# Altium schematic sheet Microcontroller.SchDoc(Microcontroller)
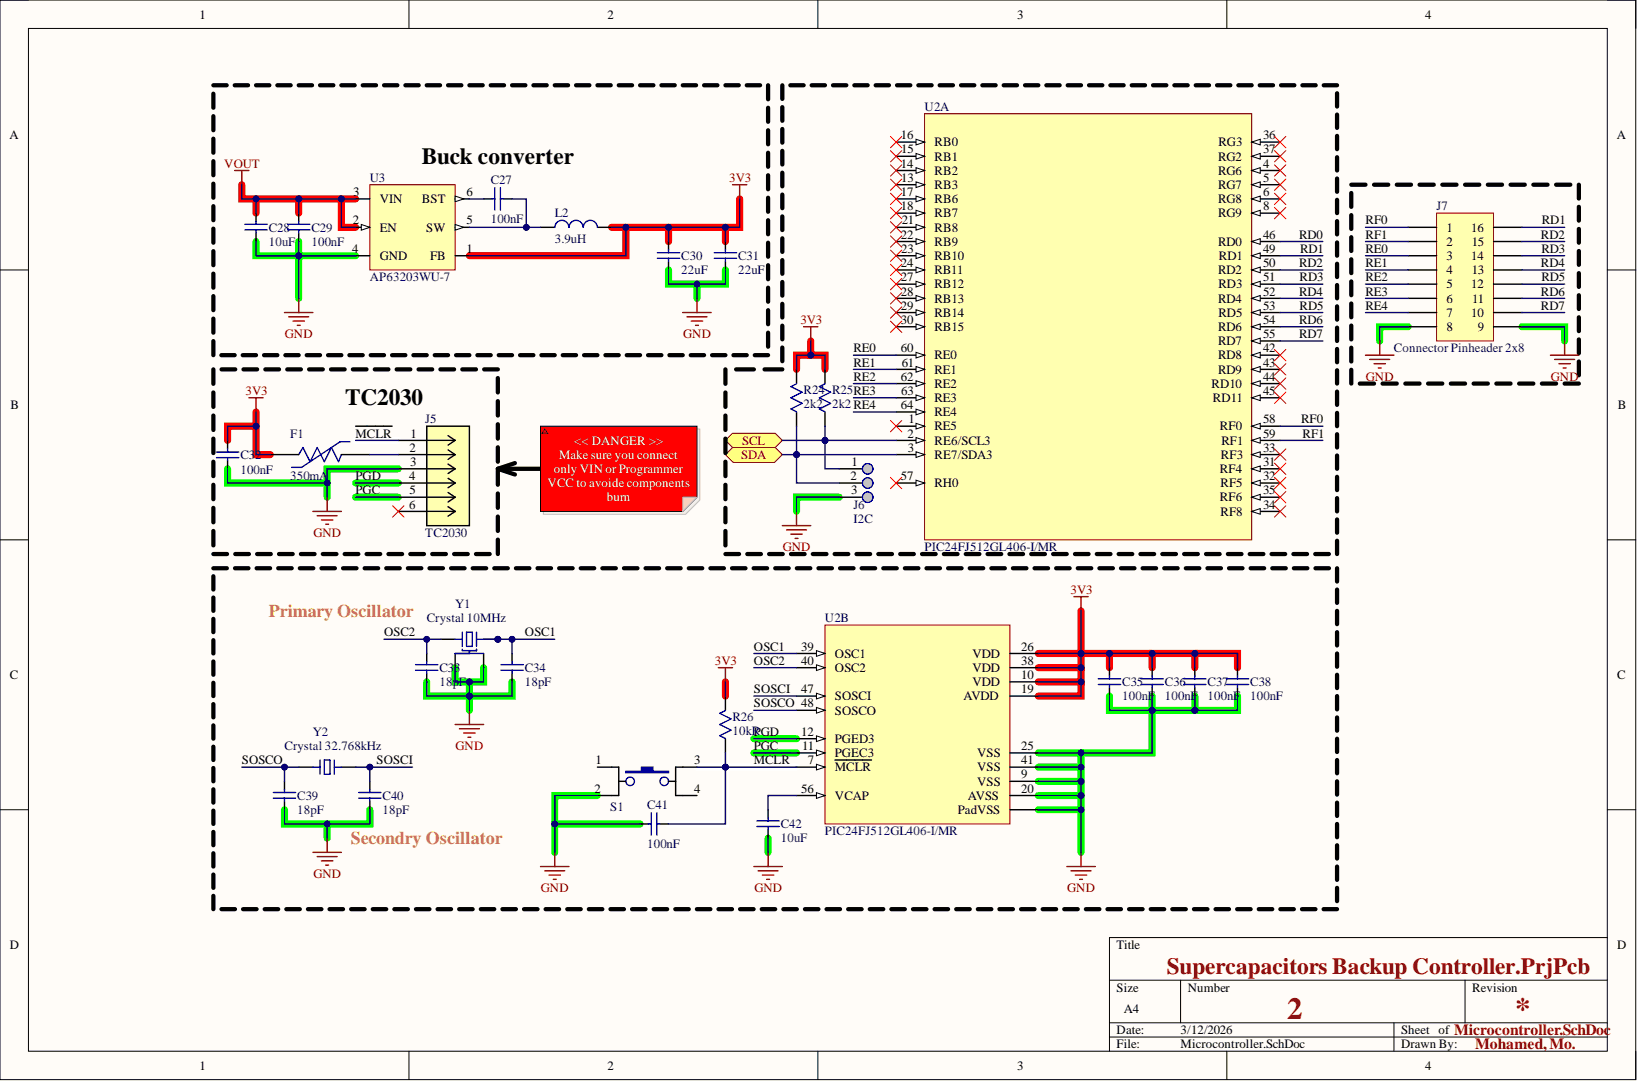
[diagram p0: Microcontroller.SchDoc]

## Parts
C27  100nF
C28  10uF
C29  100nF
C30  22uF
C31  22uF
C32  100nF
C33  18pF
C34  18pF
C35  100nF
C36  100nF
C37  100nF
C38  100nF
C39  18pF
C40  18pF
C41  100nF
C42  10uF
F1  350mA
J5  TC2030  (no BOM)
J6  I2C
J7  Connector Pinheader 2x8
L2  3.9uH
R24  2k2
R25  2k2
R26  10kR
S1  Tactile  (value not drawn)
U2  PIC24FJ512GL406-I/MR  (value not drawn)  (parts A,B)
U3  AP63203WU-7
Y1  Crystal 10MHz
Y2  Crystal 32.768kHz

## Ports
SCL, SDA

## Nets
3V3: C30.1 C31.1 C32.2 C35.1 C36.1 C37.1 C38.1 F1.1 L2.1 R24.2 R25.2 R26.2 U2.10(VDD) U2.19(AVDD) U2.26(VDD) U2.38(VDD) U3.1(FB)
GND: C28.2 C29.2 C30.2 C31.2 C32.1 C33.2 C34.2 C35.2 C36.2 C37.2 C38.2 C39.2 C40.2 C41.1 C42.2 J5.3 J6.3 J7.8 J7.9 S1.2 U2.9(VSS) U2.20(AVSS) U2.25(VSS) U2.41(VSS) U2.65(PadVSS) U3.4(GND) Y1.2 Y1.4
VOUT: C28.1 C29.1 U3.2(EN) U3.3(VIN)
~{MCLR}: C41.2 J5.1 R26.1 S1.3 U2.7(MCLR)
OSC1: C34.1 U2.39(OSC1) Y1.3
OSC2: C33.1 U2.40(OSC2) Y1.1
PGC: J5.5 U2.11(PGEC3)
PGD: J5.4 U2.12(PGED3)
RD0: U2.46(RD0)
RD1: J7.16 U2.49(RD1)
RD2: J7.15 U2.50(RD2)
RD3: J7.14 U2.51(RD3)
RD4: J7.13 U2.52(RD4)
RD5: J7.12 U2.53(RD5)
RD6: J7.11 U2.54(RD6)
RD7: J7.10 U2.55(RD7)
RE0: J7.3 U2.60(RE0)
RE1: J7.4 U2.61(RE1)
RE2: J7.5 U2.62(RE2)
RE3: J7.6 U2.63(RE3)
RE4: J7.7 U2.64(RE4)
RF0: J7.1 U2.58(RF0)
RF1: J7.2 U2.59(RF1)
SCL: J6.1 R25.1 U2.2(RE6/SCL3) ; port SCL
SDA: J6.2 R24.1 U2.3(RE7/SDA3) ; port SDA
SOSCI: C40.1 U2.47(SOSCI) Y2.2
SOSCO: C39.1 U2.48(SOSCO) Y2.1
NetC27_1: C27.1 U3.6(BST)
NetC27_2: C27.2 L2.2 U3.5(SW)
NetC42_1: C42.1 U2.56(VCAP)
NetF1_2: F1.2 J5.2

Not connected: J5 6; S1 1 4; U2 1(RE5) 4(RG6) 5(RG7) 6(RG8) 8(RG9) 13(RB3) 14(RB2) 15(RB1) 16(RB0) 17(RB6) 18(RB7) 21(RB8) 22(RB9) 23(RB10) 24(RB11) 27(RB12) 28(RB13) 29(RB14) 30(RB15) 31(RF4) 32(RF5) 33(RF3) 34(RF8) 35(RF6) 36(RG3) 37(RG2) 42(RD8) 43(RD9) 44(RD10) 45(RD11) 57(RH0)

## Library data of the parts
C27 C29 C32 C35-C38 C41 = CAP 0603 100nF 50V: Ceramic Capacitor, Multilayer, Ceramic, 50V, 10% +Tol, 10% -Tol, X7R, 15% TC, 0.1uF, Surface Mount, 0603; Manufacturer: Samsung; Manufacturer Part Number: CL10B104KB8NNNC; Multilayer: Yes; Capacitor Type: Ceramic; Case/Package: 0603; Category: Ceramic Capacitors; Component Kind: Standard; ComponentLink1Description: https://datasheet.ciiva.com:443/pdfs/VipMasterIC/IC/SEMS/SEMS-S-A0008384515/SEMS-S-A0008873300-1.pdf?src-supplier=IHS+Markit; ComponentLink1URL: https://datasheet.ciiva.com:443/pdfs/VipMasterIC/IC/SEMS/SEMS-S-A0008384515/SEMS-S-A0008873300-1.pdf?src-supplier=IHS+Markit; ComponentLink2Description: https://datasheet.ciiva.com/25803/cl10b104kb8nnnc-25803393.pdf?src-supplier=Digikey; ComponentLink2URL: https://datasheet.ciiva.com/25803/cl10b104kb8nnnc-25803393.pdf?src-supplier=Digikey; ComponentLink3Description: https://datasheet.ciiva.com/26838/getdatasheetpartid-89097-26838411.pdf?src-supplier=Verical; ComponentLink3URL: https://datasheet.ciiva.com/26838/getdatasheetpartid-89097-26838411.pdf?src-supplier=Verical; ComponentLink4Description: https://datasheet.ciiva.com/29198/456651-da-01-en-vs-kondensator-0605-29198839.pdf?src-supplier=Conrad; ComponentLink4URL: https://datasheet.ciiva.com/29198/456651-da-01-en-vs-kondensator-0605-29198839.pdf?src-supplier=Conrad; Dielectric Material: Ceramic; Height: 0.9mm; JESD-609 Code: e3; Length: 1.6mm; Library Name: Symbols.SchLib; Max Operating Temperature: 125°C; Min Operating Temperature: -55°C; Mounting Technology: Surface Mount; Package Shape: Rectangular; Package Style: SMTMeter; Packaging: Tape and Reel; Pins: 2; Pricing: 1=0.1, 10=0.026, 50=0.0146, 100=0.0114, 500=0.00856, 1000=0.00672, 4000=0.00562, 8000=0.00513, 12000=0.00489, 28000=0.00464, 100000=0.00336, 200000=0.00325, 400000=0.00306 (USD); Rated DC Voltage (URdc): 50V; Reach SVHC Compliant: Yes; RoHS: Yes; RoHS Compliant: Yes; Stock: 6712361; Supplier: Digikey; Supplier Part Number: 1276-1000-1-ND; Temperature Characteristics Code: X7R; Terminal Finish: Nickel; Termination: Wraparound; Tolerance  (fill in as +-): 10%; Tolerance (fill in as +-): 10%; Value: 100nF; Width: 0.8mm
C28 = CAP 1206 10uF 1%: Ceramic Capacitor, Multilayer, Ceramic, 50V, 10% +Tol, 10% -Tol, X7R, 15% TC, 10uF, Surface Mount, 1206; Manufacturer: Samsung; Manufacturer Part Number: CL31B106KBHNNNE; Multilayer: Yes; Capacitor Type: Ceramic; Case/Package: 1206; Category: Ceramic Capacitors; Component Kind: Standard; ComponentLink1Description: https://datasheet.ciiva.com:443/pdfs/VipMasterIC/IC/SEMS/SEMS-S-A0008524842/SEMS-S-A0008524770-1.pdf?src-supplier=IHS+Markit; ComponentLink1URL: https://datasheet.ciiva.com:443/pdfs/VipMasterIC/IC/SEMS/SEMS-S-A0008524842/SEMS-S-A0008524770-1.pdf?src-supplier=IHS+Markit; ComponentLink2Description: https://datasheet.octopart.com/CL31B106KBHNNNE-Samsung-Electro-Mechanics-datasheet-149066874.pdf?src-supplier=Future+Electronics; ComponentLink2URL: https://datasheet.octopart.com/CL31B106KBHNNNE-Samsung-Electro-Mechanics-datasheet-149066874.pdf?src-supplier=Future+Electronics; ComponentLink3Description: http://www.samsungsem.com/kr/support/product-search/mlcc/CL31B106KBHNNNE.jsp?src-supplier=Digikey; ComponentLink3URL: http://www.samsungsem.com/kr/support/product-search/mlcc/CL31B106KBHNNNE.jsp?src-supplier=Digikey; ComponentLink4Description: https://datasheet.octopart.com/CL31B106KBHNNNE-Samsung-Electro-Mechanics-datasheet-110617700.pdf?src-supplier=LCSC; ComponentLink4URL: https://datasheet.octopart.com/CL31B106KBHNNNE-Samsung-Electro-Mechanics-datasheet-110617700.pdf?src-supplier=LCSC; Dielectric Material: Ceramic; Height: 1.8mm; JESD-609 Code: e3; Length: 3.2mm; Library Name: Symbols.SchLib; Max Operating Temperature: 125°C; Min Operating Temperature: -55°C; Mounting Technology: Surface Mount; Package Shape: Rectangular; Package Style: SMTMeter; Packaging: Tape and Reel; Pins: 2; Pricing: 1=0.33, 10=0.216, 50=0.1592, 100=0.1296, 500=0.10234, 1000=0.08641 (USD); Rated DC Voltage (URdc): 50V; Reach SVHC Compliant: Yes; RoHS: Yes; RoHS Compliant: Yes; Stock: 418195; Supplier: Digikey; Supplier Part Number: 1276-6767-1-ND; Temperature Characteristics Code: X7R; Terminal Finish: Nickel; Termination: Wraparound; Tolerance  (fill in as +-): 10%; Tolerance (fill in as +-): 10%; Value: 10uF; Width: 1.6mm
C30 C31 = CAP 0603 22uF 6.3V: Ceramic Capacitor, Multilayer, Ceramic, 6.3V, 20% +Tol, 20% -Tol, X5R, 15% TC, 22uF, Surface Mount, 0603; Manufacturer: Samsung; Manufacturer Part Number: CL10A226MQ8NRNC; Multilayer: Yes; Capacitor Type: Ceramic; Case/Package: 0603; Category: Ceramic Capacitors; Component Kind: Standard; ComponentLink1Description: https://datasheet.ciiva.com:443/pdfs/VipMasterIC/IC/SEMS/SEMS-S-A0008525088/SEMS-S-A0008525204-1.pdf?src-supplier=IHS+Markit; ComponentLink1URL: https://datasheet.ciiva.com:443/pdfs/VipMasterIC/IC/SEMS/SEMS-S-A0008525088/SEMS-S-A0008525204-1.pdf?src-supplier=IHS+Markit; ComponentLink2Description: https://datasheet.ciiva.com/25790/cl10a226mq8nrnc-25790695.pdf?src-supplier=Digikey; ComponentLink2URL: https://datasheet.ciiva.com/25790/cl10a226mq8nrnc-25790695.pdf?src-supplier=Digikey; ComponentLink3Description: https://datasheet.ciiva.com/26838/getdatasheetpartid-353311-26838372.pdf?src-supplier=Verical; ComponentLink3URL: https://datasheet.ciiva.com/26838/getdatasheetpartid-353311-26838372.pdf?src-supplier=Verical; ComponentLink4Description: https://datasheet.ciiva.com/29198/457121-da-01-en-vs-kondensator-0603-29198747.pdf?src-supplier=Conrad; ComponentLink4URL: https://datasheet.ciiva.com/29198/457121-da-01-en-vs-kondensator-0603-29198747.pdf?src-supplier=Conrad; ComponentLink5Description: https://datasheet.ciiva.com/16437/cl05b104kq5nnnc-16437317.pdf?src-supplier=Future+Electronics; ComponentLink5URL: https://datasheet.ciiva.com/16437/cl05b104kq5nnnc-16437317.pdf?src-supplier=Future+Electronics; Dielectric Material: Ceramic; Height: 1mm; JESD-609 Code: e3; Length: 1.6mm; Library Name: Symbols.SchLib; Max Operating Temperature: 85°C; Min Operating Temperature: -55°C; Mounting Technology: Surface Mount; Package Shape: Rectangular; Package Style: SMTMeter; Packaging: Tape and Reel; Pins: 2; Pricing: 1=0.16, 10=0.094, 50=0.0666, 100=0.0581, 500=0.0437, 1000=0.03922 (USD); Rated DC Voltage (URdc): 6.3V; Reach SVHC Compliant: Yes; RoHS: Yes; RoHS Compliant: Yes; Stock: 2901331; Supplier: Digikey; Supplier Part Number: 1276-1193-1-ND; Temperature Characteristics Code: X5R; Terminal Finish: Nickel; Termination: Wraparound; Tolerance  (fill in as +-): 20%; Tolerance (fill in as +-): 20%; Value: 22uF; Width: 0.8mm
C33 C34 C39 C40 = CAP 0603 18pF 50V: Ceramic Capacitor, Multilayer, Ceramic, 50V, 5% +Tol, 5% -Tol, C0G, 30ppm/Cel TC, 0.000018uF, Surface Mount, 0603; Manufacturer: Yageo; Manufacturer Part Number: CC0603JRNPO9BN180; Multilayer: Yes; Capacitor Type: Ceramic; Case/Package: 0603; Category: Ceramic Capacitors; Component Kind: Standard; ComponentLink1Description: https://datasheet.ciiva.com:443/pdfs/VipMasterIC/IC/YAGO/YAGO-S-A0009251638/YAGO-S-A0009251638-1.pdf?src-supplier=IHS+Markit; ComponentLink1URL: https://datasheet.ciiva.com:443/pdfs/VipMasterIC/IC/YAGO/YAGO-S-A0009251638/YAGO-S-A0009251638-1.pdf?src-supplier=IHS+Markit; ComponentLink2Description: https://datasheet.ciiva.com/144/mlccorderingcode-144638.pdf?src-supplier=Digikey; ComponentLink2URL: https://datasheet.ciiva.com/144/mlccorderingcode-144638.pdf?src-supplier=Digikey; ComponentLink3Description: https://datasheet.ciiva.com/144/mlccorderingcode-144637.pdf?src-supplier=Digikey; ComponentLink3URL: https://datasheet.ciiva.com/144/mlccorderingcode-144637.pdf?src-supplier=Digikey; ComponentLink4Description: https://datasheet.ciiva.com/144/mlccorderingcode-144639.pdf?src-supplier=Digikey; ComponentLink4URL: https://datasheet.ciiva.com/144/mlccorderingcode-144639.pdf?src-supplier=Digikey; ComponentLink5Description: https://datasheet.ciiva.com/28450/1443161-28450477.pdf?src-supplier=Element14; ComponentLink5URL: https://datasheet.ciiva.com/28450/1443161-28450477.pdf?src-supplier=Element14; ComponentLink6Description: https://datasheet.ciiva.com/46430/1835847-46430152.pdf?src-supplier=Element14; ComponentLink6URL: https://datasheet.ciiva.com/46430/1835847-46430152.pdf?src-supplier=Element14; ComponentLink7Description: https://datasheet.ciiva.com/5425/upy-gp-np0-16v-to-50v-8-5425439.pdf?src-supplier=Mouser; ComponentLink7URL: https://datasheet.ciiva.com/5425/upy-gp-np0-16v-to-50v-8-5425439.pdf?src-supplier=Mouser; ComponentLink8Description: https://datasheet.ciiva.com/8033/1734582-8033733.pdf?src-supplier=Element14; ComponentLink8URL: https://datasheet.ciiva.com/8033/1734582-8033733.pdf?src-supplier=Element14; ComponentLink9Description: https://datasheet.ciiva.com/11587/upy-gp-np0-16v-to-50v-8-11587323.pdf?src-supplier=Avnet; ComponentLink9URL: https://datasheet.ciiva.com/11587/upy-gp-np0-16v-to-50v-8-11587323.pdf?src-supplier=Avnet; Dielectric Material: Ceramic; Height: 0.8mm; JESD-609 Code: e3; Length: 1.6mm; Library Name: Symbols.SchLib; Max Operating Temperature: 125°C; Min Operating Temperature: -55°C; Mounting Technology: Surface Mount; Package Shape: Rectangular; Package Style: SMTMeter; Packaging: Tape and Reel; Pins: 2; Pricing: 1=0.1, 10=0.035, 50=0.0202, 100=0.0156, 500=0.01178, 1000=0.00925 (USD); Rated DC Voltage (URdc): 50V; Reach SVHC Compliant: Yes; RoHS: Yes; RoHS Compliant: Yes; Stock: 500372; Supplier: Digikey; Supplier Part Number: 311-1061-1-ND; Temperature Characteristics Code: C0G; Terminal Finish: Nickel; Termination: Wraparound; Tolerance  (fill in as +-): 5%; Tolerance (fill in as +-): 5%; Value: 18pF; Width: 0.8mm
C42 = CAP 0603 10uF 10V: Ceramic Capacitor, Multilayer, Ceramic, 10V, 10% +Tol, 10% -Tol, X5R, 15% TC, 10uF, Surface Mount, 0603; Manufacturer: Cal-Chip Electronics; Manufacturer Part Number: GMC10X5R106K10NT; Multilayer: Yes; Capacitor Type: Ceramic; Case/Package: 0603; Component Kind: Standard; ComponentLink1Description: https://datasheet.ciiva.com:443/pdfs/VipMasterIC/IC/CALC/CALC-S-A0005264378/CALC-S-A0005264378-1.pdf?src-supplier=IHS+Markit; ComponentLink1URL: https://datasheet.ciiva.com:443/pdfs/VipMasterIC/IC/CALC/CALC-S-A0005264378/CALC-S-A0005264378-1.pdf?src-supplier=IHS+Markit; Dielectric Material: Ceramic; Height: 0.8mm; JESD-609 Code: e3; Length: 1.6mm; Library Name: Symbols.SchLib; Max Operating Temperature: 85°C; Min Operating Temperature: -55°C; Mounting Technology: Surface Mount; Package Shape: Rectangular; Package Style: SMTMeter; Packaging: Tape and Reel; Pins: 2; Pricing: 1=0.18, 10=0.114, 50=0.072, 100=0.0576, 500=0.0416, 1000=0.0368 (USD); Rated DC Voltage (URdc): 10V; Reach SVHC Compliant: Yes; RoHS: Yes; RoHS Compliant: Yes; Stock: 59006; Supplier: Digikey; Supplier Part Number: 4713-GMC10X5R106K10NTCT-ND; Temperature Characteristics Code: X5R; Terminal Finish: Nickel; Termination: Wraparound; Tolerance  (fill in as +-): 10%; Tolerance (fill in as +-): 10%; Value: 10uF; Width: 0.8mm
F1 = Fuse 350mA: PTC Resettable Fuse, 30V, 0.3ohm, Surface Mount; Manufacturer: Bel; Manufacturer Part Number: 0ZCJ0035AF2E; Package Height: 1.3mm; Package Length: 3.5mm; Package Width: 1.8mm; Reference Standard: UL; Category: PTC Resettable Fuses; Component Kind: Standard; ComponentLink1Description: https://datasheet.ciiva.com:443/pdfs/VipMasterIC/IC/BELF/BELF-S-A0003129735/BELF-S-A0003129735-1.pdf?src-supplier=IHS+Markit; ComponentLink1URL: https://datasheet.ciiva.com:443/pdfs/VipMasterIC/IC/BELF/BELF-S-A0003129735/BELF-S-A0003129735-1.pdf?src-supplier=IHS+Markit; ComponentLink2Description: https://datasheet.ciiva.com/18550/0zcj-18550696.pdf?src-supplier=Digikey; ComponentLink2URL: https://datasheet.ciiva.com/18550/0zcj-18550696.pdf?src-supplier=Digikey; ComponentLink3Description: https://datasheet.ciiva.com/18550/0zcj-18550697.pdf?src-supplier=Digikey; ComponentLink3URL: https://datasheet.ciiva.com/18550/0zcj-18550697.pdf?src-supplier=Digikey; Hold Current: 350mA; JESD-609 Code: e3; Library Name: Symbols.SchLib; Max Operating Temperature: 85°C; Min Operating Temperature: -40°C; Pricing: 1=0.2, 5=0.162, 10=0.15, 50=0.1252, 100=0.1157, 250=0.10424, 500=0.09634, 1000=0.089 (USD); Reach SVHC Compliant: Yes; Resistor Type: PTC THERMISTOR; RoHS: Yes; RoHS Compliant: Yes; Stock: 695164; Supplier: Digikey; Supplier Part Number: 507-1801-1-ND; Terminal Finish: Tin; Working Voltage: 30V
J6 = Headers 3P: Component Kind: Standard; Library Name: Symbols.SchLib
J7 = Connector Pinheader 2x8: Header_2X8; Component Kind: Standard; Library Name: Symbols.SchLib
L2 = IND 3.9uH 30%: General Purpose Inductor, 3.9uH, 30%, 1 Element, Ferrite-Core, SMD, 2424; Manufacturer: Murata; Manufacturer Part Number: 1255AY-3R9N=P3; Channels: 1; DC Resistance (DCR): 26.4mR; Inductor Application: DC-DC CONVERTER; Max Current Rating: 4.2A; Shape/Size Description: RECTANGULAR PACKAGE; Shielding: Shielded; Test Frequency: 100kHz; Category: Fixed Inductors; Component Kind: Standard; ComponentLink1Description: https://psearch.en.murata.com:443/inductor/product/1255AY-3R9N_.pdf?src-supplier=IHS+Markit; ComponentLink1URL: https://psearch.en.murata.com:443/inductor/product/1255AY-3R9N_.pdf?src-supplier=IHS+Markit; ComponentLink2Description: http://www.toko.co.jp/products/pdf/inductors/dg6045c.pdf?src-supplier=Digikey; ComponentLink2URL: http://www.toko.co.jp/products/pdf/inductors/dg6045c.pdf?src-supplier=Digikey; Core Material: Ferrite; Inductance-Nom (L): 3.9uH; Inductor Type: GENERAL PURPOSE INDUCTOR; Library Name: Symbols.SchLib; Max Operating Temperature: 85°C; Min Operating Temperature: -40°C; Pins: 2; Pricing: 1=0.45, 10=0.389, 25=0.3568, 50=0.3244, 100=0.2888, 250=0.27252, 500=0.2336 (USD); Reach SVHC Compliant: Yes; RoHS: Yes; RoHS Compliant: Yes; Stock: 3229; Supplier: Digikey; Supplier Part Number: 490-14062-1-ND; Surface Mount: Yes; Terminal Placement: Dual Ended; Termination: Wraparound; Tolerance: 30%
R24 R25 = RES 0603 2K2 1%: Fixed Resistor, Metal Glaze/thick Film, 0.1W, 2200ohm, 75V, 1% +/-Tol, 100ppm/Cel, Surface Mount, 0603; Manufacturer: Yageo; Manufacturer Part Number: RC0603FR-072K2L; Additional Feature: LASER TRIMMABLE; Package Height: 0.45mm; Package Length: 1.6mm; Package Width: 0.8mm; Power: 100mW; Rated Temperature: 70°C; Temperature Coefficient: 100ppm/°C; Case/Package: 0603; Category: Chip SMD Resistors; Component Kind: Standard; ComponentLink1Description: https://datasheet.ciiva.com:443/pdfs/VipMasterIC/IC/YAGO/YAGO-S-A0008145938/YAGO-S-A0008145938-1.pdf?src-supplier=IHS+Markit; ComponentLink1URL: https://datasheet.ciiva.com:443/pdfs/VipMasterIC/IC/YAGO/YAGO-S-A0008145938/YAGO-S-A0008145938-1.pdf?src-supplier=IHS+Markit; ComponentLink2Description: https://datasheet.ciiva.com/2453/pyu-r-int-thick-7-2453943.pdf?src-supplier=Digikey; ComponentLink2URL: https://datasheet.ciiva.com/2453/pyu-r-int-thick-7-2453943.pdf?src-supplier=Digikey; ComponentLink3Description: https://datasheet.ciiva.com/2453/pyu-r-int-thick-7-2453944.pdf?src-supplier=Digikey; ComponentLink3URL: https://datasheet.ciiva.com/2453/pyu-r-int-thick-7-2453944.pdf?src-supplier=Digikey; ComponentLink4Description: https://datasheet.ciiva.com/2453/pyu-r-int-thick-7-2453945.pdf?src-supplier=Digikey; ComponentLink4URL: https://datasheet.ciiva.com/2453/pyu-r-int-thick-7-2453945.pdf?src-supplier=Digikey; ComponentLink5Description: https://datasheet.ciiva.com/7660/1868787-7660791.pdf?src-supplier=Element14; ComponentLink5URL: https://datasheet.ciiva.com/7660/1868787-7660791.pdf?src-supplier=Element14; ComponentLink6Description: https://datasheet.ciiva.com/7746/1738695-7746316.pdf?src-supplier=Element14; ComponentLink6URL: https://datasheet.ciiva.com/7746/1738695-7746316.pdf?src-supplier=Element14; ComponentLink7Description: https://datasheet.ciiva.com/7746/1738695-7746315.pdf?src-supplier=Element14; ComponentLink7URL: https://datasheet.ciiva.com/7746/1738695-7746315.pdf?src-supplier=Element14; Construction: Chip; JESD-609 Code: e3; Library Name: Symbols.SchLib; Max Operating Temperature: 155°C; Min Operating Temperature: -55°C; Mounting Technology: Surface Mount; Package Shape: Rectangular; Package Style: SMTMeter; Packaging: Tape and Reel; Pins: 2; Pricing: 1=0.1, 10=0.019, 50=0.0112, 100=0.0093, 500=0.00622, 1000=0.00532 (USD); Reach SVHC Compliant: Yes; Resistor Type: FIXED RESISTOR; RoHS: Yes; RoHS Compliant: Yes; Stock: 885954; Supplier: Digikey; Supplier Part Number: 311-2.20KHRCT-ND; Technology: METAL GLAZE/THICK FILM; Terminal Finish: Nickel; Termination: Wraparound; Tolerance: 1%; Value: 2k2; Working Voltage: 75V
R26 = RES 0603 10kR 1%: Fixed Resistor, Metal Glaze/thick Film, 0.1W, 10000ohm, 75V, 1% +/-Tol, 100ppm/Cel, Surface Mount, 0603; Manufacturer: Yageo; Manufacturer Part Number: RC0603FR-0710KL; Additional Feature: LASER TRIMMABLE; Package Height: 0.45mm; Package Length: 1.6mm; Package Width: 0.8mm; Power: 100mW; Rated Temperature: 70°C; Resistance: 10k; Temperature Coefficient: 100ppm/°C; Case/Package: 0603; Category: Chip SMD Resistors; Component Kind: Standard; ComponentLink1Description: https://datasheet.ciiva.com:443/pdfs/VipMasterIC/IC/YAGO/YAGO-S-A0008145938/YAGO-S-A0008145938-1.pdf?src-supplier=IHS+Markit; ComponentLink1URL: https://datasheet.ciiva.com:443/pdfs/VipMasterIC/IC/YAGO/YAGO-S-A0008145938/YAGO-S-A0008145938-1.pdf?src-supplier=IHS+Markit; ComponentLink2Description: https://datasheet.ciiva.com/46440/1868787-46440891.pdf?src-supplier=Element14; ComponentLink2URL: https://datasheet.ciiva.com/46440/1868787-46440891.pdf?src-supplier=Element14; ComponentLink3Description: https://datasheet.ciiva.com/7746/1738695-7746239.pdf?src-supplier=Element14; ComponentLink3URL: https://datasheet.ciiva.com/7746/1738695-7746239.pdf?src-supplier=Element14; ComponentLink4Description: https://datasheet.ciiva.com/7983/443971-7983596.pdf?src-supplier=Element14; ComponentLink4URL: https://datasheet.ciiva.com/7983/443971-7983596.pdf?src-supplier=Element14; ComponentLink5Description: https://datasheet.ciiva.com/7660/38590-7660789.pdf?src-supplier=Element14; ComponentLink5URL: https://datasheet.ciiva.com/7660/38590-7660789.pdf?src-supplier=Element14; ComponentLink6Description: https://datasheet.ciiva.com/22747/443971-22747519.pdf?src-supplier=Element14; ComponentLink6URL: https://datasheet.ciiva.com/22747/443971-22747519.pdf?src-supplier=Element14; ComponentLink7Description: https://datasheet.ciiva.com/15189/getdatasheetpartid-409289-15189591.pdf?src-supplier=Verical; ComponentLink7URL: https://datasheet.ciiva.com/15189/getdatasheetpartid-409289-15189591.pdf?src-supplier=Verical; Construction: Chip; JESD-609 Code: e3; Library Name: Symbols.SchLib; Max Operating Temperature: 155°C; Min Operating Temperature: -55°C; Mounting Technology: Surface Mount; Package Shape: Rectangular; Package Style: SMTMeter; Packaging: Tape and Reel; Pins: 2; Pricing: 1=0.1, 10=0.019, 50=0.0112, 100=0.0093, 500=0.00622, 1000=0.00532 (USD); Reach SVHC Compliant: Yes; Resistor Type: FIXED RESISTOR; RoHS: Yes; RoHS Compliant: Yes; Stock: 9812185; Supplier: Digikey; Supplier Part Number: 311-10.0KHRCT-ND; Technology: METAL GLAZE/THICK FILM; Terminal Finish: Nickel; Termination: Wraparound; Tolerance: 1%; Value: 10kR; Working Voltage: 75V
S1 = Tactile: Switch Tactile N.O. SPST Round Button Gull Wing 0.05A 12VDC 200000Cycles 2.45N SMD T/R; Manufacturer: ITT C&K; Manufacturer Part Number: PTS526SK15SMTR2LFS; Component Kind: Standard; ComponentLink1Description: https://dznh3ojzb2azq.cloudfront.net/products/Tactile/PTS526/documents/datasheet.pdf?src-supplier=Digikey; ComponentLink1URL: https://dznh3ojzb2azq.cloudfront.net/products/Tactile/PTS526/documents/datasheet.pdf?src-supplier=Digikey; ComponentLink2Description: https://datasheet.octopart.com/PTS526SK15SMTR2LFS-C%26K-Components-datasheet-138817219.pdf?src-supplier=Future+Electronics; ComponentLink2URL: https://datasheet.octopart.com/PTS526SK15SMTR2LFS-C%26K-Components-datasheet-138817219.pdf?src-supplier=Future+Electronics; Library Name: Symbols.SchLib; Pricing: 1=0.14, 10=0.135, 25=0.1276, 100=0.1162, 250=0.1092, 500=0.09988, 1000=0.08363 (USD); RoHS: No; Stock: 286192; Supplier: Digikey; Supplier Part Number: CKN12221-1-ND
U2 = PIC24FJ512GL406-I/MR: RISC Microcontroller, 16-Bit, FLASH, 32MHz, CMOS, PQCC64; Manufacturer: Microchip; Category: Microcontrollers; Component Kind: Standard; ComponentLink1Description: http://ww1.microchip.com/downloads/en/DeviceDoc/PIC24FJ512GU410-Family-Data-Sheet-DS30010203C.pdf?src-supplier=Digikey; ComponentLink1URL: http://ww1.microchip.com/downloads/en/DeviceDoc/PIC24FJ512GU410-Family-Data-Sheet-DS30010203C.pdf?src-supplier=Digikey; Library Name: Symbols.SchLib; Manufacturer Part Number: PIC24FJ512GL406-I/MR; Pricing: 1=3.83, 25=3.51, 100=3.17 (USD); RoHS: No; Stock: 34; Supplier: Digikey; Supplier Part Number: 150-PIC24FJ512GL406-I/MR-ND
U3 = AP63203WU-7: Switching Regulator; Manufacturer: Diodes; Category: Voltage Regulators - Switching; Component Kind: Standard; ComponentLink1Description: https://datasheet.ciiva.com:443/pdfs/VipMasterIC/IC/DIOD/DIOD-S-A0007089856/DIOD-S-A0007089856-1.pdf?src-supplier=IHS+Markit; ComponentLink1URL: https://datasheet.ciiva.com:443/pdfs/VipMasterIC/IC/DIOD/DIOD-S-A0007089856/DIOD-S-A0007089856-1.pdf?src-supplier=IHS+Markit; ComponentLink2Description: https://www.diodes.com/assets/Datasheets/AP63200-AP63201-AP63203-AP63205.pdf?src-supplier=Digikey; ComponentLink2URL: https://www.diodes.com/assets/Datasheets/AP63200-AP63201-AP63203-AP63205.pdf?src-supplier=Digikey; Library Name: Symbols.SchLib; Manufacturer Part Number: AP63203WU-7; Pricing: 1=0.87, 10=0.761, 25=0.7152, 100=0.5836, 250=0.54212, 500=0.46136, 1000=0.36909 (USD); RoHS: Yes; Stock: 33990; Supplier: Digikey; Supplier Part Number: AP63203WU-7DICT-ND
Y1 = Crystal 10MHz: Crystal 10.000MHZ 12PF SMD; Manufacturer: Raltron Electronics; Manufacturer Part Number: RH100-10.000-12-3050-EXT-TR-NS1; Category: LDO; Component Kind: Standard; Library Name: Symbols.SchLib; Pricing: 1=0.18, 10=0.146, 50=0.1318, 100=0.1172, 500=0.1113, 1000=0.09373 (USD); RoHS: No; Stock: 2975; Supplier: Digikey; Supplier Part Number: 2151-RH100-10.000-12-3050-EXT-TR-NS1CT-ND
Y2 = Crystal 32.768kHz: PTC Resettable Fuse, 30V, 0.3ohm, Surface Mount; Manufacturer: Abracon; Manufacturer Part Number: ABS07-32.768KHZ-T; Additional Feature: TAPE AND REEL; Aging: 3 PPM/FIRST YEAR; Crystal/Resonator Type: PARALLEL - FUNDAMENTAL; Drive Level: 0.5uW; ESR: 70kR; Frequency Tolerance: 20ppm; Load Capacitance: 12.5pF; Operating Frequency-Nom: 0.032768; Package Height: 1.3mm; Package Length: 3.5mm; Package Width: 1.8mm; Reference Standard: UL; Category: Crystals; Component Kind: Standard; ComponentLink1Description: https://datasheet.ciiva.com:443/pdfs/VipMasterIC/IC/ABRC/ABRC-S-A0006623177/ABRC-S-A0006623177-1.pdf?src-supplier=IHS+Markit; ComponentLink1URL: https://datasheet.ciiva.com:443/pdfs/VipMasterIC/IC/ABRC/ABRC-S-A0006623177/ABRC-S-A0006623177-1.pdf?src-supplier=IHS+Markit; ComponentLink2Description: https://datasheet.ciiva.com/7998/1912628-7998667.pdf?src-supplier=Element14; ComponentLink2URL: https://datasheet.ciiva.com/7998/1912628-7998667.pdf?src-supplier=Element14; ComponentLink3Description: https://datasheet.ciiva.com/1357/1883680-1357319.pdf?src-supplier=Element14; ComponentLink3URL: https://datasheet.ciiva.com/1357/1883680-1357319.pdf?src-supplier=Element14; ComponentLink4Description: https://datasheet.ciiva.com/854/abs07-854936.pdf?src-supplier=Digikey; ComponentLink4URL: https://datasheet.ciiva.com/854/abs07-854936.pdf?src-supplier=Digikey; ComponentLink5Description: https://datasheet.ciiva.com/854/abs07-854935.pdf?src-supplier=Digikey; ComponentLink5URL: https://datasheet.ciiva.com/854/abs07-854935.pdf?src-supplier=Digikey; ComponentLink6Description: https://datasheet.ciiva.com/854/abs07-854937.pdf?src-supplier=Digikey; ComponentLink6URL: https://datasheet.ciiva.com/854/abs07-854937.pdf?src-supplier=Digikey; ComponentLink7Description: https://datasheet.ciiva.com/4944/abs07-11028-4944830.pdf?src-supplier=Mouser; ComponentLink7URL: https://datasheet.ciiva.com/4944/abs07-11028-4944830.pdf?src-supplier=Mouser; ComponentLink8Description: https://datasheet.ciiva.com/15214/getdatasheetpartid-727011-15214954.pdf?src-supplier=Verical; ComponentLink8URL: https://datasheet.ciiva.com/15214/getdatasheetpartid-727011-15214954.pdf?src-supplier=Verical; ComponentLink9Description: https://datasheet.ciiva.com:443/pdfs/VipMasterIC/IC/BELF/BELF-S-A0003129735/BELF-S-A0003129735-1.pdf?src-supplier=IHS+Markit; ComponentLink9URL: https://datasheet.ciiva.com:443/pdfs/VipMasterIC/IC/BELF/BELF-S-A0003129735/BELF-S-A0003129735-1.pdf?src-supplier=IHS+Markit; ComponentLink10Description: https://datasheet.ciiva.com/18550/0zcj-18550696.pdf?src-supplier=Digikey; ComponentLink10URL: https://datasheet.ciiva.com/18550/0zcj-18550696.pdf?src-supplier=Digikey; ComponentLink11Description: https://datasheet.ciiva.com/18550/0zcj-18550697.pdf?src-supplier=Digikey; ComponentLink11URL: https://datasheet.ciiva.com/18550/0zcj-18550697.pdf?src-supplier=Digikey; JESD-609 Code: e3; Library Name: Symbols.SchLib; Max Operating Temperature: 85°C; Min Operating Temperature: -40°C; Mounting Technology: Surface Mount; Pricing: 1=0.65, 10=0.563, 50=0.507, 100=0.4849, 500=0.43742, 1000=0.4185 (USD); Reach SVHC Compliant: Yes; Resistor Type: PTC THERMISTOR; RoHS: Yes; RoHS Compliant: Yes; Stock: 1391380; Supplier: Digikey; Supplier Part Number: 535-9542-1-ND; Terminal Finish: Tin; Working Voltage: 30V
J5 = TC2030: Library Name: Symbols.SchLib
